# Altium schematic sheet USB_DEV.SchDoc(USB_DEV)
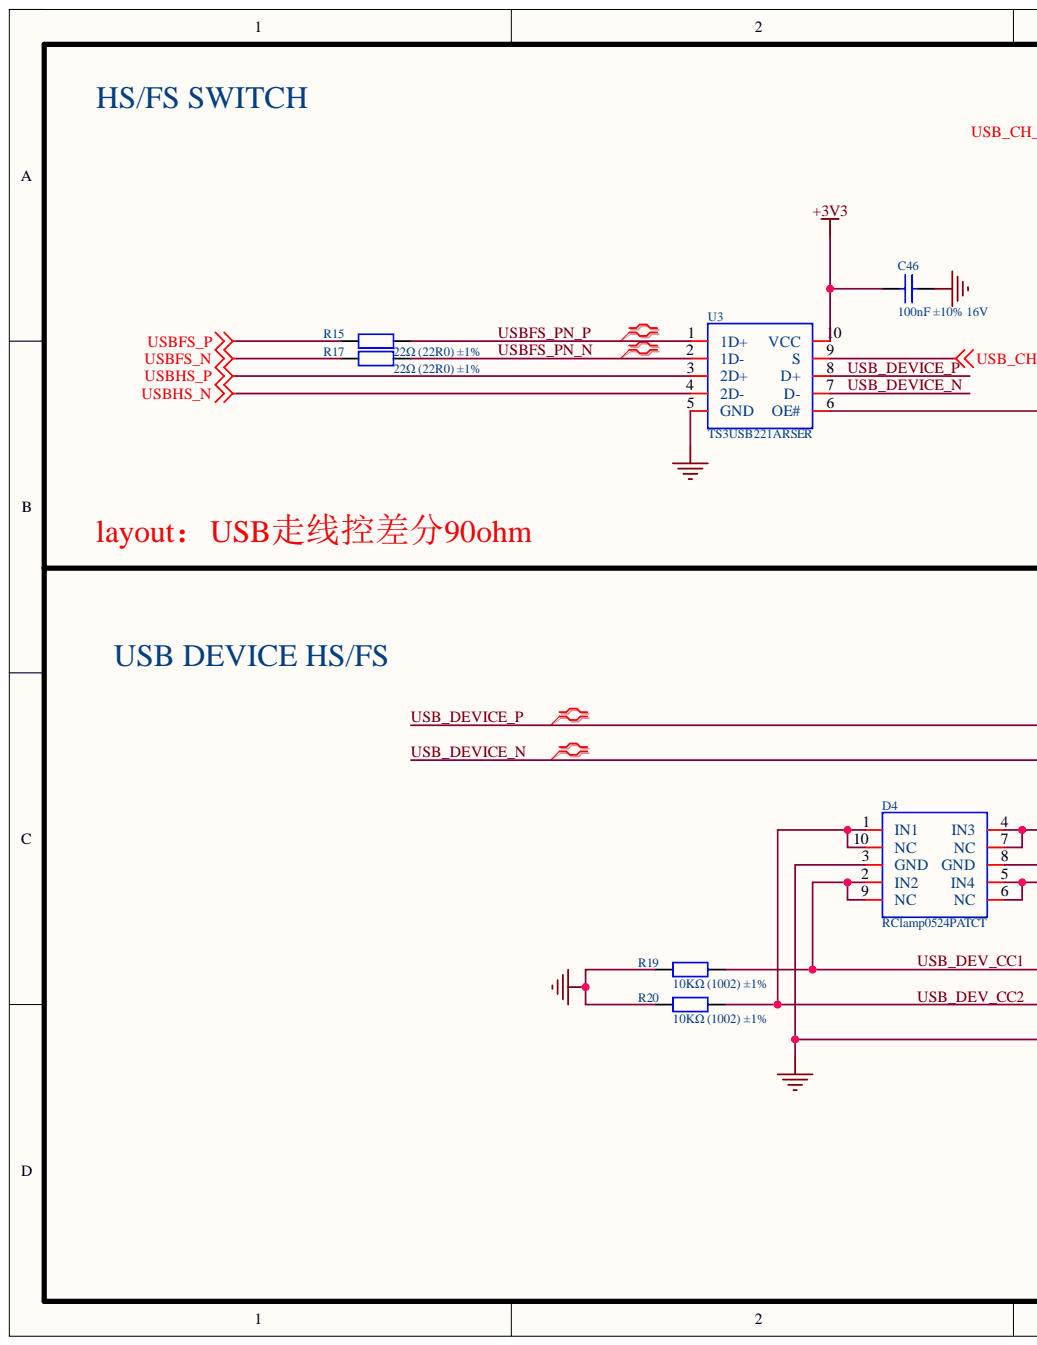
[diagram p0: USB_DEV.SchDoc, left]
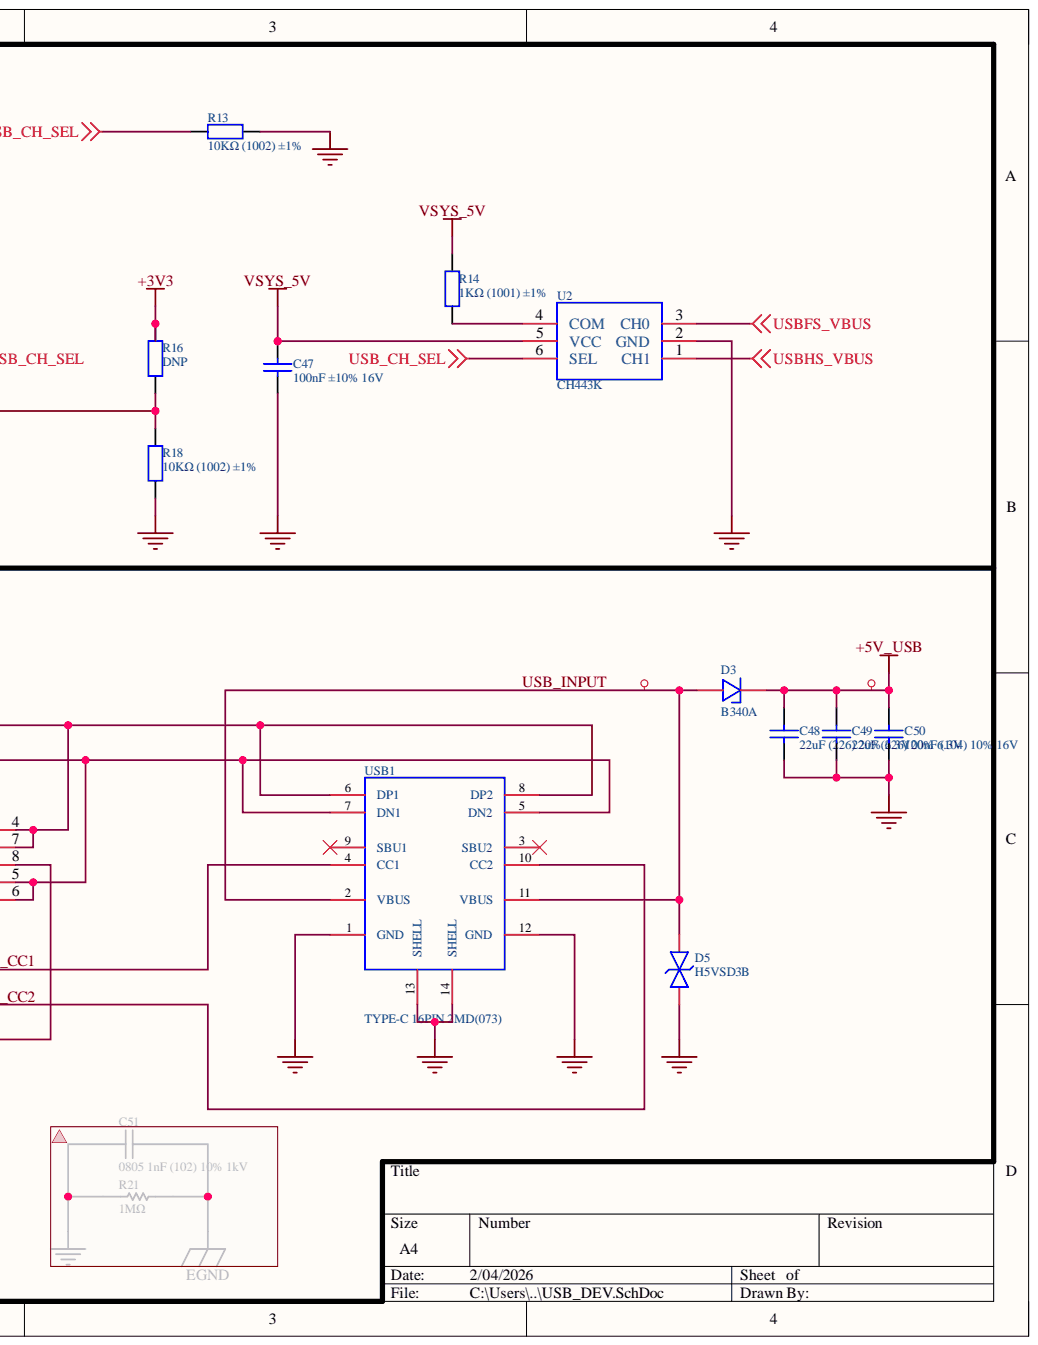
[diagram p1: USB_DEV.SchDoc, right]

## Parts
C46  100nF ±10% 16V  Capacitance: 100nF  Voltage: 16V  [C0201]
C47  100nF ±10% 16V  Capacitance: 100nF  [C0201]
C48  22uF (226) 20% 6.3V  [C0603]
C49  22uF (226) 20% 6.3V  [C0603]
C50  100nF (104) 10% 16V  [C0402]
D3  B340A  [SMA_L4.3-W2.6-LS5.1-RD]
D4  RClamp0524PATCT  [SLP2510P8_L2.5-W1.0-P0.50-BL]
D5  H5VSD3B  [SOD-323_L1.7-W1.3-LS2.5-RD]
R13  10KΩ (1002) ±1%  [R0402]
R14  1KΩ (1001) ±1%  [R0402]
R15  22Ω (22R0) ±1%  [R0402]
R16  DNP  [R0402]
R17  22Ω (22R0) ±1%  [R0402]
R18  10KΩ (1002) ±1%  [R0402]
R19  10KΩ (1002) ±1%  [R0402]
R20  10KΩ (1002) ±1%  [R0402]
U2  CH443K  [SC70-6_L2.1-W1.3-P0.65-LS2.3-BL]
U3  TS3USB221ARSER  [UQFN-10_L2.0-W1.5-P0.50-BL]
USB1  TYPE-C 16PIN 2MD(073)  [USB-C-SMD_TYPE-C-6PIN-2MD-073]

## Nets
+3V3: C46.1 R16.2 U3.10(VCC)
+5V_USB: C48.2 C49.2 C50.1 D3.2
GND: C46.2 C47.2 C48.1 C49.1 C50.2 D4.3(GND) D4.8(GND) D5.1 R13.1 R18.1 R19.2 R20.2 U2.2(GND) U3.5(GND) USB1.1(GND) USB1.12(GND) USB1.13(SHELL) USB1.14(SHELL)
USB_CH_SEL: R13.2 U2.6(SEL) U3.9(S)
USBFS_N: R17.1
USBFS_P: R15.2
USBFS_VBUS: U2.3(CH0)
USBHS_N: U3.4(2D-)
USBHS_P: U3.3(2D+)
USBHS_VBUS: U2.1(CH1)
VSYS_5V: C47.1 R14.2 U2.5(VCC)
USB_DEV_CC1: D4.2(IN2) D4.9(NC) R19.1 USB1.4(CC1)
USB_DEV_CC2: D4.1(IN1) D4.10(NC) R20.1 USB1.10(CC2)
USB_DEVICE_N: D4.5(IN4) D4.6(NC) U3.7(D-) USB1.5(DN2) USB1.7(DN1)
USB_DEVICE_P: D4.4(IN3) D4.7(NC) U3.8(D+) USB1.6(DP1) USB1.8(DP2)
USB_INPUT: D3.1 D5.2 USB1.2(VBUS) USB1.11(VBUS)
USBFS_PN_N: R17.2 U3.2(1D-)
USBFS_PN_P: R15.1 U3.1(1D+)
NetR14_1: R14.1 U2.4(COM)
NetR16_1: R16.1 R18.2 U3.6(OE#)

Not connected: USB1 3(SBU2) 9(SBU1)

## Library data of the parts
C46 C47 = CAP-000030-01: 0201,100nF ±10% 16V，X7R; Legacy Part Number: 151-10004022; Manufacturer: muRata; Manufacturer Part Number 1: GRM033Z71C104KE14D; Dialectric: X7R; Notes: ANY EQUIVALENT; ClassName: MCU; Component Kind: Standard; ComponentLink1Description: New link; ComponentLink1URL: https://item.szlcsc.com/698285.html?fromZone=l_c__%2522catalog%2522&spm=sc.cid.xh3.zy.n___sc.gb.xh1.zy.l&lcsc_vid=FgdZAQZURgVfVlRQQQNbBAcFQVZYX1NeQ1BYX10ARFkxVlNSQ1FZUVReQVdaUjsOAxUeFF5JWAgaAglIBBsCBBcFWQIBCks%3D; Library Name: RA8P1.SCHLIB; Manufacturer 1: muRata; Manufacturer 3: AVX; Manufacturer Part Number 3: 0402YC104KAT2A; Mfg: muRata; Mfg P/N: GRM033Z71C104KE14D; Mfg Part Number: GRM033Z71C104KE14D; Package: 0402; PN: GRM033Z71C104KE14D; Supplier 1: Arrow Electronics; Supplier 2: Digi-Key; Supplier 3: Newark; Supplier 4: Mouser; Supplier Part Number 1: CC0402KRX7R8BB104; Supplier Part Number 2: 311-2077-1-ND; Supplier Part Number 3: 40AJ6370; Supplier Part Number 4: 603-CC402KRX7R8BB104; Tolerance: 10%
  C47: Voltage: 16V
C48 C49 = 0603 22uF (226) 20% 6.3V: 贴片电容; Component Kind: Standard; ComponentLink1Description: 供应商链接; ComponentLink1URL: http://www.szlcsc.com/product/details_60514.html; Library Name: RA8P1.SCHLIB; Package: 0603; Supplier: LC; SuppliersPartNumber: C59461
C50 = 0402 100nF (104) 10% 16V: 贴片电容; Component Kind: Standard; ComponentLink1Description: 供应商链接; ComponentLink1URL: https://item.szlcsc.com/42839.html; Library Name: RA8P1.SCHLIB; Package: 0402; Supplier: LC; SuppliersPartNumber: C1525
D3 = B340A: 40V,550mV@3A; Manufacturer: MDD(辰达半导体); Manufacturer Part: SS54; Add into BOM: yes; Diode Configuration: 独立式; Forward Voltage (Vf@If): 550mV@5.0A; Rectified Current: 5A; Reverse Leakage Current (Ir): 50uA@40V; Component Kind: Standard; Convert to PCB: yes; Datasheet: https://atta.szlcsc.com/upload/public/pdf/source/20230828/254C3882F8647358AD9F60DD73611B5E.pdf; Device: B340A; JLCPCB Part Class: Extended Part; LCSC Part Name: 电压:40V 电流:3A; Library Name: ra8p1_v0.1.SCHLIB; PCB3D: SMA_L4.3-W2.6-LS5.0-FD; Reverse Voltage (Vr): 40V; Supplier: LCSC; Supplier Footprint: SMA(DO-214AC); Symbol: B340A
D4 = RClamp0524PATCT: ESD; Manufacturer: SEMTECH; Add into BOM: yes; Breakdown Voltage: 6V; Device: RClamp0524PATCT_C40960; Maximum Clamping Voltage: 15V; Number of Lines: 四路; Peak Pulse Power Dissipation (Ppp): 150W; Reverse Stand-Off Voltage (Vrwm): 5V; Component Kind: Standard; Convert to PCB: yes; Datasheet: https://atta.szlcsc.com/upload/public/pdf/source/20150124/1457707260739.pdf; JLCPCB Part Class: Extended Part; LCSC Part Name: RClamp0524PATCT; Library Name: RA8P1.SCHLIB; Manufacturer Part: RClamp0524PATCT; Operating Temperature: -55℃~+125℃@(Tj); PCB3D: SLP2510-8P_L2.5-W1.0-H0.5; Supplier: LCSC; Supplier Footprint: DFN-10(1x2.5); Supplier Part: C40960; Symbol: RClamp0524PATCT; Type: ESD
D5 = H5VSD3B: TVS-ESD,22V,16.9A; Manufacturer: 宏嘉诚; Add into BOM: yes; Clamping Voltage: 20V; Peak Pulse Current (Ipp): 25A; Peak Pulse Power Dissipation (Ppp): 500W; Polarity: 双向; Reverse Leakage Current (Ir): 0.2μA; Reverse Stand-Off Voltage (Vrwm): 5V; Symbol: SMBJ22CA_C19077577; Type: TVS; Voltage - Breakdown: 9V; Component Kind: Standard; Convert to PCB: yes; Datasheet: https://atta.szlcsc.com/upload/public/pdf/source/20250107/474F05451FC8AB27D7FA0238914F96F6.pdf; Device: H5VSD3B; JLCPCB Part Class: Extended Part; LCSC Part Name: H5VSD3B; Library Name: RA8P1.SCHLIB; Manufacturer Part: H5VSD3B; Operating Temperature: -; Supplier: LCSC; Supplier Footprint: SOD-323; Supplier Part: C20615806
R13 R16 R18-R20 = 0402 10KΩ (1002) 1%: 贴片电阻，10K; Component Kind: Standard; ComponentLink1Description: 供应商链接; ComponentLink1URL: https://item.szlcsc.com/26487.html?fromZone=l_b__%2522brand%2522&spm=sc.bid.xh1.zy.n&lcsc_vid=FANcVFBQFQMNX1cFFAdZVVZXEgdeAgdWFlQNUVAHT1ExVlNSRFhXVlJQQFlYVzsOAxUeFF5JWA0HFBBIBBsCBBcFWQIBCksEBQAAA0tfTk8GEwkK; Library Name: RA8P1.SCHLIB; Package: 0402; Supplier: LC; SuppliersPartNumber: C25744
R14 = 0402 1KΩ (1001) 1%: 贴片电阻; Component Kind: Standard; ComponentLink1Description: 供应商链接; ComponentLink1URL: http://www.szlcsc.com/product/details_12256.html; Library Name: RA8P1.SCHLIB; Package: 0402; Supplier: LC; SuppliersPartNumber: C11702
R15 R17 = 0402 22Ω (22R0) 1%: 贴片电阻; Component Kind: Standard; ComponentLink1Description: 供应商链接; ComponentLink1URL: http://www.szlcsc.com/product/details_25835.html; Library Name: RA8P1.SCHLIB; Package: 0402; Supplier: LC; SuppliersPartNumber: C25092
U2 = CH443K: Manufacturer: WCH(南京沁恒); Add into BOM: yes; Component Kind: Standard; Convert to PCB: yes; Datasheet: https://atta.szlcsc.com/upload/public/pdf/source/20200819/C125185_598679904862E814E7C3CDA2CBF564BC.pdf; Device: CH443K; JLCPCB Part Class: Extended Part; LCSC Part Name: 1单刀双掷5V低阻模拟开关芯片; Library Name: RA8P1.SCHLIB; Manufacturer Part: CH443K; PCB3D: SC70-6_L2.1-W1.3-P0.65-LS2.3-BL; Supplier: LCSC; Supplier Footprint: SC-70-6(SOT-363); Supplier Part: C125185; Switch Circuit: -; Symbol: CH443K
U3 = TS3USB221ARSER: 模拟开关，usb专用; Manufacturer: TI(德州仪器); -3dB Bandwidth: 900MHz; Add into BOM: yes; RDS(on): 6Ω; Switch Circuit: 单刀双掷(SPDT); Voltage - Supply: 2.5V~3.3V; Component Kind: Standard; Convert to PCB: yes; Datasheet: https://www.ti.com/cn/lit/gpn/ts3usb221a; Device: TS3USB221ARSER; JLCPCB Part Class: Extended Part; LCSC Part Name: TS3USB221A ESD保护、高速USB2.0 (480-Mbps) 1:2多路复用器和解复用器开关，带单使能; Library Name: RA8P1.SCHLIB; Manufacturer Part: TS3USB221ARSER; Operating Temperature: -40℃~+85℃; PCB3D: UQFN-10_L2.0-W1.5-H0.6-P0.50; Supplier: LCSC; Supplier Footprint: UQFN-10(1.5x2); Supplier Part: C128396; Symbol: TS3USB221ARSER
USB1 = TYPE-C 16PIN 2MD(073): 卧贴,5V,3A; Component Kind: Standard; Library Name: RA8P1.SCHLIB; Symbol: TYPE-C 16PIN 2MD(073)
